# Altium schematic sheet RCU_v2_Supply.SchDoc(RCU_v2_Supply)
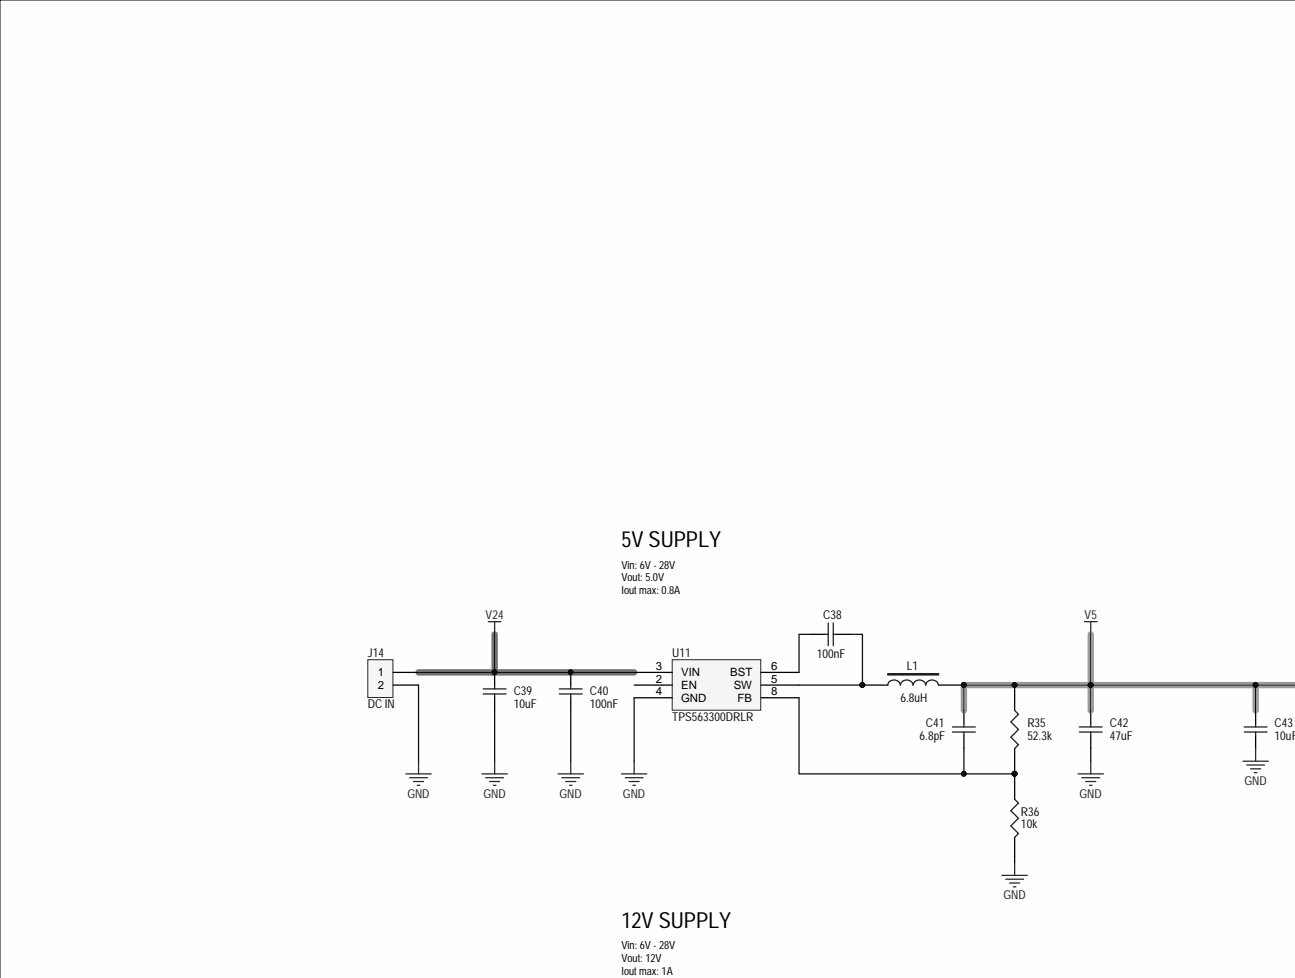
[diagram p0: RCU_v2_Supply.SchDoc, top left]
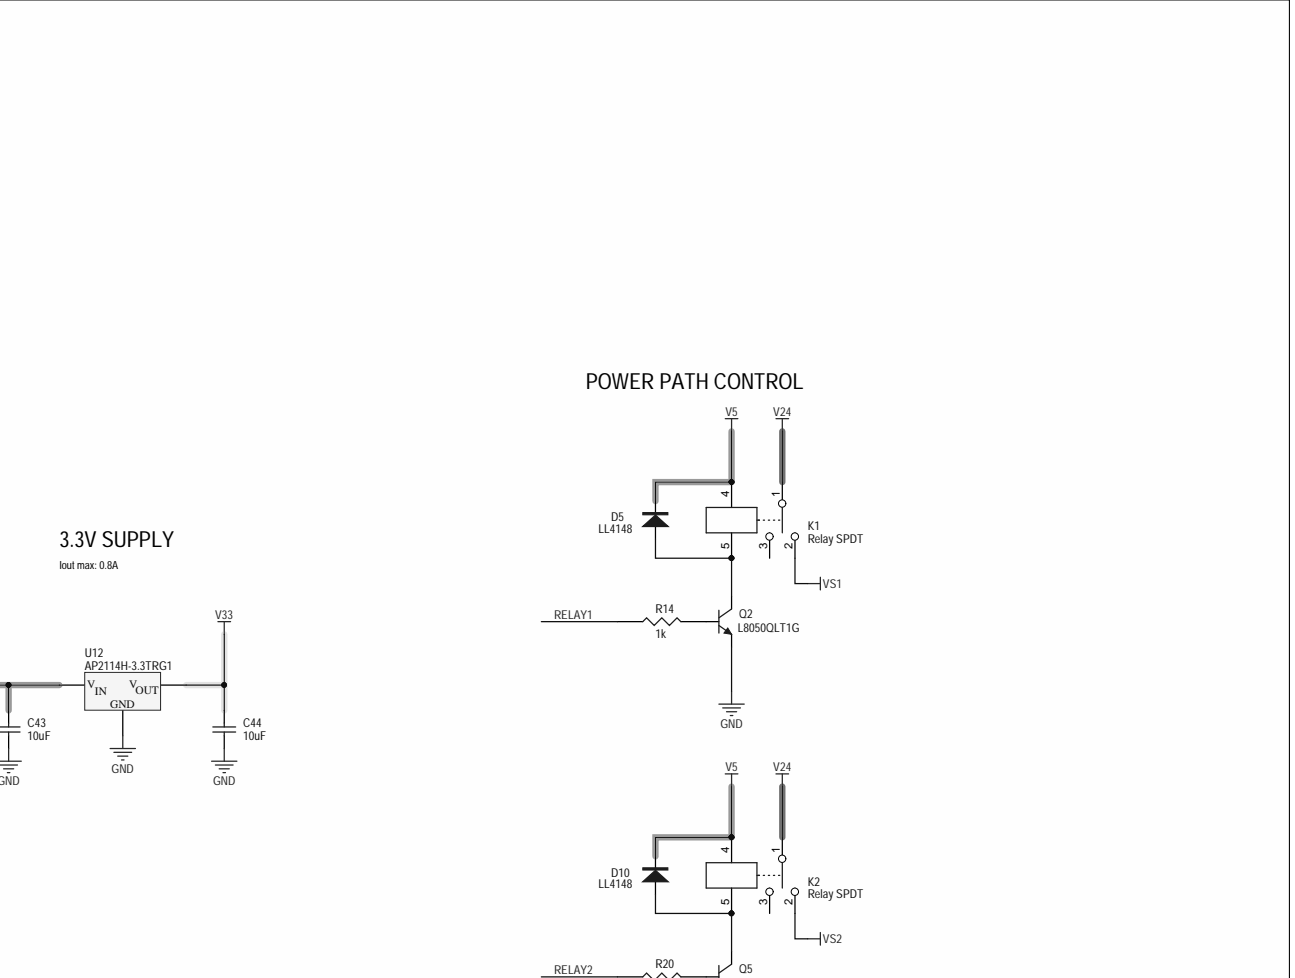
[diagram p1: RCU_v2_Supply.SchDoc, top right]
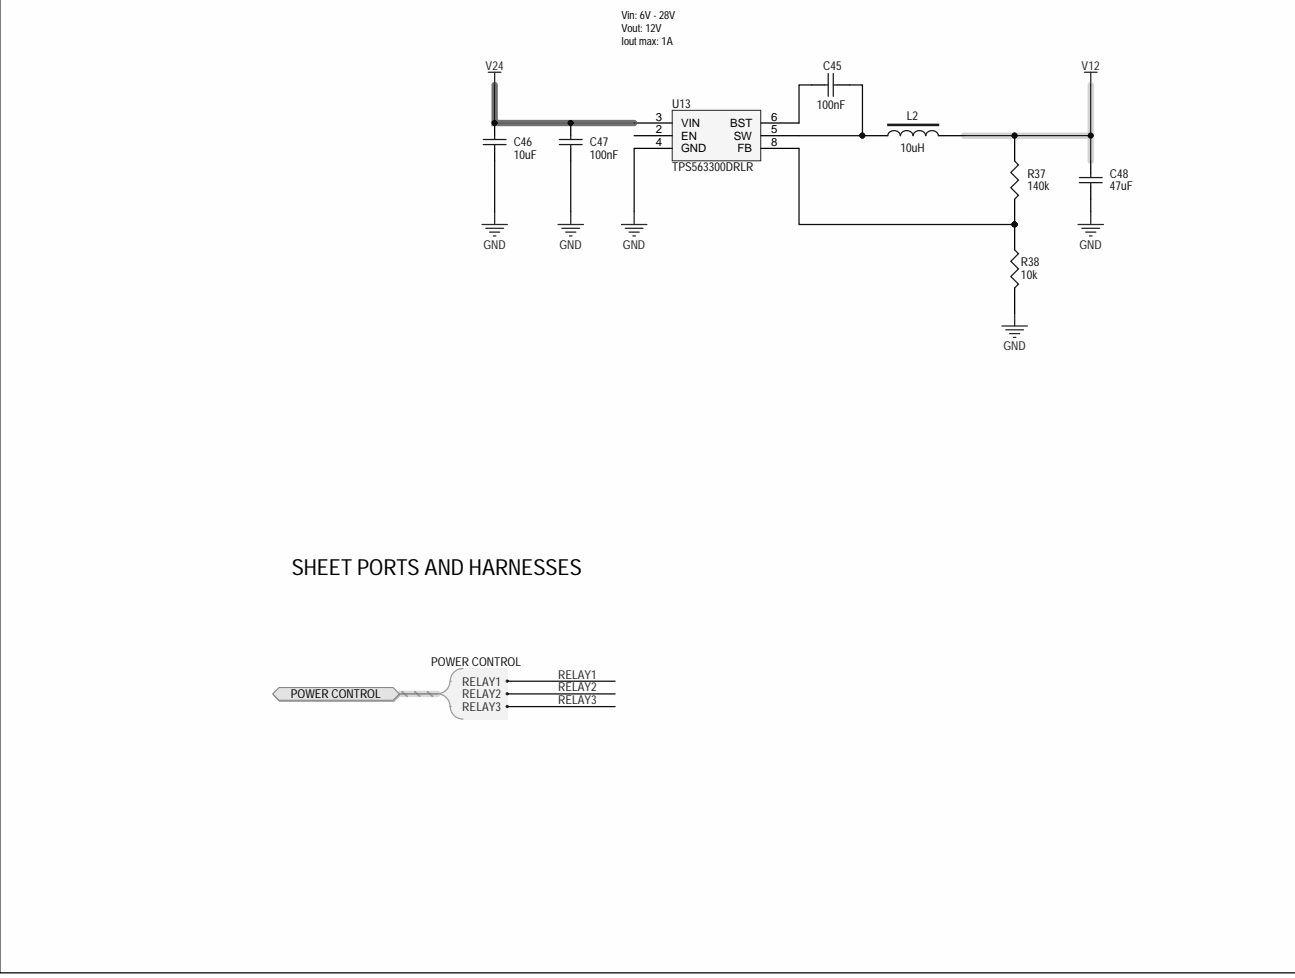
[diagram p2: RCU_v2_Supply.SchDoc, bottom left]
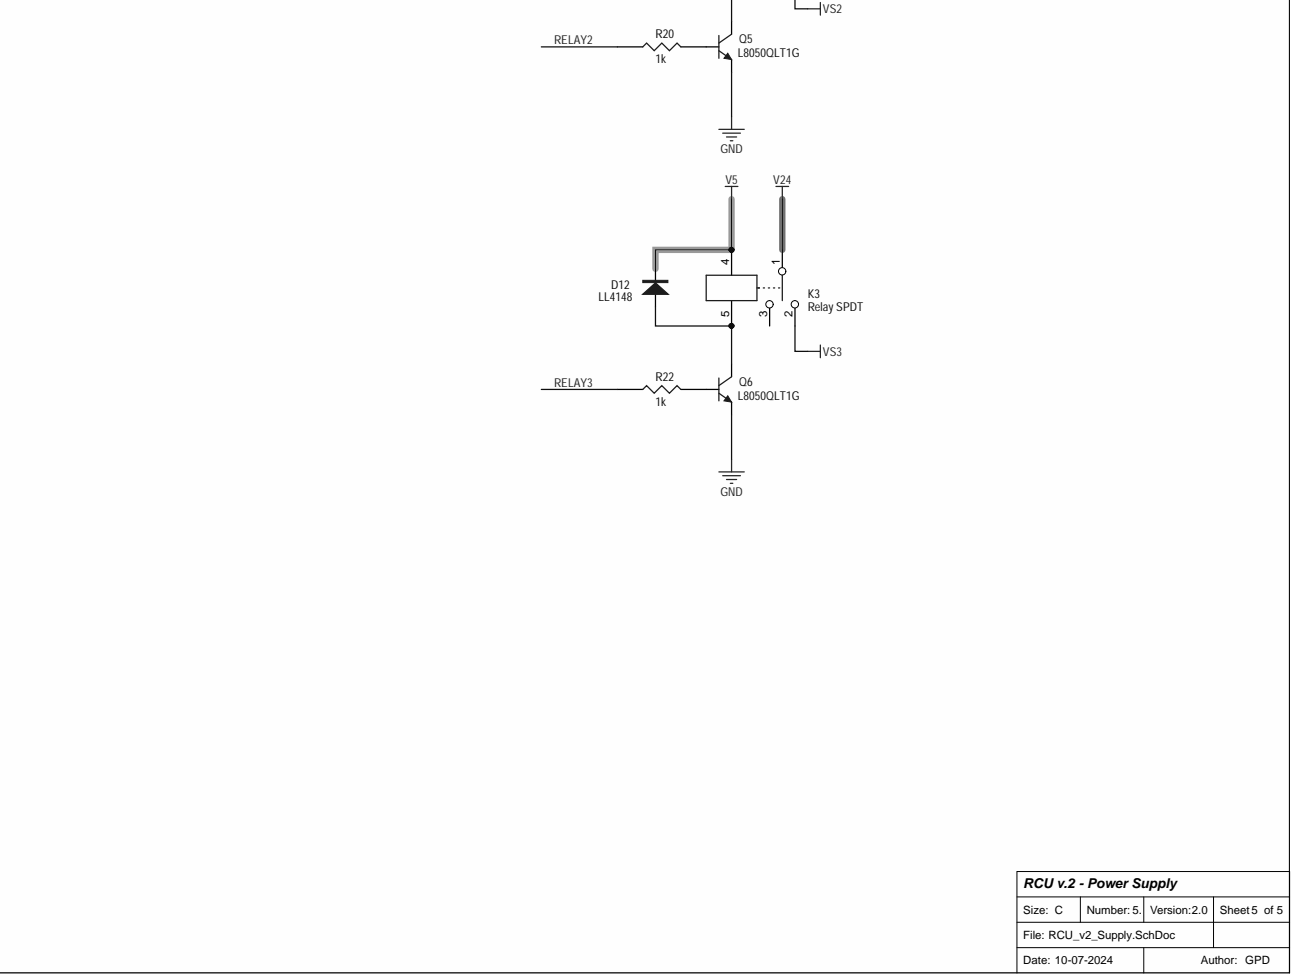
[diagram p3: RCU_v2_Supply.SchDoc, bottom right]

## Parts
C38  100nF  [C0402]
C39  10uF  [C1206]
C40  100nF  [C0603]
C41  6.8pF  [C0402]
C42  47uF  [C1210]
C43  10uF  [C0603]
C44  10uF  [C0603]
C45  100nF  [C0402]
C46  10uF  [C1206]
C47  100nF  [C0603]
C48  47uF  [C1210]
D5  LL4148  [SOD123]
D10  LL4148  [SOD123]
D12  LL4148  [SOD123]
J14  DC IN  [SIP2]
K1  Relay SPDT  [RELAY_AT1RC5V]
K2  Relay SPDT  [RELAY_AT1RC5V]
K3  Relay SPDT  [RELAY_AT1RC5V]
L1  6.8uH  [COIL9]
L2  10uH  [COIL_10]
Q2  L8050QLT1G  [NPN_SMT]
Q5  L8050QLT1G  [NPN_SMT]
Q6  L8050QLT1G  [NPN_SMT]
R14  1k  [R0402]
R20  1k  [R0402]
R22  1k  [R0402]
R35  52.3k  [R0402]
R36  10k  [R0402]
R37  140k  [R0402]
R38  10k  [R0402]
U11  TPS563300DRLR  [SOT-5X3 - 0.6 mm]
U12  AP2114H-3.3TRG1  [DSO-G3/C6.6]
U13  TPS563300DRLR  [SOT-5X3 - 0.6 mm]

## Ports
POWER CONTROL, POWER CONTROL.RELAY1, POWER CONTROL.RELAY2, POWER CONTROL.RELAY3

## Nets
GND: C39.2 C40.2 C42.1 C43.2 C44.2 C46.2 C47.2 C48.1 J14.2 Q2.3 Q5.3 Q6.3 R36.2 R38.2 U11.4(GND) U12.1 U13.4(GND)
V5: C41.1 C42.2 C43.1 D5.2 D10.2 D12.2 K1.4 K2.4 K3.4 L1.2 R35.1 U12.3
V12: C48.2 L2.2 R37.1
V24: C39.1 C40.1 C46.1 C47.1 J14.1 K1.1 K2.1 K3.1 U11.3(VIN) U13.3(VIN)
V33: C44.1 U12.2
VS1: K1.2
VS2: K2.2
VS3: K3.2
RELAY1: R14.1 ; port POWER CONTROL
RELAY2: R20.1 ; port POWER CONTROL
RELAY3: R22.1 ; port POWER CONTROL
NetC38_1: C38.1 U11.6(BST)
NetC38_2: C38.2 L1.1 U11.5(SW)
NetC41_2: C41.2 R35.2 R36.1 U11.8(FB)
NetC45_1: C45.1 U13.6(BST)
NetC45_2: C45.2 L2.1 U13.5(SW)
NetD5_1: D5.1 K1.5 Q2.1
NetD10_1: D10.1 K2.5 Q5.1
NetD12_1: D12.1 K3.5 Q6.1
NetQ2_2: Q2.2 R14.2
NetQ5_2: Q5.2 R20.2
NetQ6_2: Q6.2 R22.2
NetR37_2: R37.2 R38.1 U13.8(FB)

Not connected: K1 3; K2 3; K3 3; U11 2(EN); U13 2(EN)

## Library data of the parts
C38 C45 = CAP: 100nF ±10% 16V X7R 0402 Multilayer Ceramic Capacitors MLCC - SMD/SMT RoHS; Manufacturer Part Number: C0402C104K4RAC-TU; Manufacturer: KEMET; Value: 100nF; Supplier Part Number 3: 399-C0402C104K4RAC7867DKR-ND; Supplier Part Number 2: 8015145; Supplier Part Number 1: 80-C0402C104K4R; Supplier 3: Digikey; Supplier 2: Rs; Supplier 1: Mouser; Library Name: Miscellaneous Devices.SchLib; Component Kind: Standard
C39 C46 = CAP: Multilayer Ceramic Capacitor, 10 uF, 50 V, ± 20%, X5R, 1206 [3216 Metric]; Manufacturer Part Number: UMK316BBJ106ML-T; Manufacturer: Taiyo Yuden; Value: 10uF; Supplier Part Number 3: C97712; Supplier Part Number 2: 963-UMK316BBJ106ML-T; Supplier Part Number 1: 587-3248-6-ND; Supplier 3: LCSC; Supplier 2: Mouser; Supplier 1: Digikey; Library Name: Miscellaneous Devices.SchLib; Component Kind: Standard
C40 C47 = CAP: Multilayer Ceramic Capacitor, 0.1 uF, 50 V, ± 10%, X7R, 0603 [1608 Metric]; Manufacturer Part Number: CC0603KPX7R9BB104; Manufacturer: Yageo; Value: 100nF; Supplier Part Number 3: 603-CC603KPX7R9BB104; Supplier Part Number 2: 3764509RL; Supplier Part Number 1: 311-3567-6-ND; Supplier 3: Mouser; Supplier 2: Farnell; Supplier 1: Digikey; Library Name: Miscellaneous Devices.SchLib; Component Kind: Standard
C41 = CAP: Cap Ceramic 6.8pF 25V C0G 0.25pF SMD 0402 125C Paper T/R; Manufacturer Part Number: GRM1555C1E6R8CA01D; Manufacturer: Murata; Value: 6.8pF; Supplier Part Number 3: C3877782; Supplier Part Number 2: 81-GRM1555C1E6R8CA1D; Supplier Part Number 1: 490-11371-1-ND; Supplier 3: LCSC; Supplier 2: Mouser; Supplier 1: Digikey; Library Name: Miscellaneous Devices.SchLib; Component Kind: Standard
C42 C48 = CAP: Multilayer Ceramic Capacitor, 47 uF, 16 V, ± 10%, X5R, 1210 [3225 Metric]; Manufacturer Part Number: CL32A476KOJNNNE; Manufacturer: Samsung; Value: 47uF; Supplier Part Number 3: 1276-3376-1-ND; Supplier Part Number 2: C2932477; Supplier Part Number 1: 187-CL32A476KOJNNNE; Supplier 3: Digikey; Supplier 2: LCSC; Supplier 1: Mouser; Library Name: Miscellaneous Devices.SchLib; Component Kind: Standard
C43 C44 = CAP: Multilayer Ceramic Capacitor, 10 uF, 16 V, ± 20%, X6S, 0603 [1608 Metric]; Manufacturer Part Number: GRM188C81C106MA73D; Manufacturer: Murata; Value: 10uF; Supplier Part Number 3: 81-GRM188C81C106MA3D; Supplier Part Number 2: 490-7198-1-ND; Supplier Part Number 1: C182375; Supplier 3: Mouser; Supplier 2: Digikey; Supplier 1: LCSC; Library Name: Miscellaneous Devices.SchLib; Component Kind: Standard
D5 D10 D12 = 1N4148: Rectifier Diode Small Signal Switching 100V 0.15A 4ns 2-Pin Mini-MELF T/R; Manufacturer: Diotec; Value: LL4148; Supplier Part Number 3: 637-LL4148; Supplier Part Number 2: 4878-LL4148DKR-ND; Supplier Part Number 1: 4878-LL4148CT-ND; Supplier 3: Mouser; Supplier 2: Digikey; Supplier 1: Digikey; Simulation: 1N4148; Manufacturer Part Number: LL4148; Component Kind: Standard
J14 = CON2: Header Assembly 2 Position MTA100 SPCL BEND MTA-100 Series | TE Connectivity 644874-2; Value: DC IN; Supplier Part Number 2: A114207-ND; Supplier Part Number 1: 571-644874-2; Supplier 2: Digikey; Supplier 1: Mouser; Manufacturer Part Number: 644874-2; Manufacturer: TE Connectivity; Library Name: Miscellaneous Devices.SchLib; Component Kind: Standard
K1-K3 = RELAY-SPDT: SPDT Non Latching Plugin Power Relays Coil 3VDC Contacts 10A 250VAC; Manufacturer Part Number: SRD-05VDC-SL-C; Manufacturer: Ningbo Forward Relay; Value: Relay SPDT; Supplier Part Number 3: C35449; Supplier Part Number 2: C35449; Supplier Part Number 1: C35449; Supplier 3: LCSC; Supplier 2: LCSC; Supplier 1: LCSC; Component Kind: Standard
L1 = INDUCTOR2: Power Choke Shielded Wirewound 6.8uH 20% 100KHz Ferrite 5.5A 22.2mOhm DCR T/R; Manufacturer Part Number: 7443340680; Manufacturer: Wurth Electronics; Value: 6.8uH; Supplier Part Number 3: 2211637; Supplier Part Number 2: 732-4182-6-ND; Supplier Part Number 1: 710-7443340680; Supplier 3: Farnell; Supplier 2: Digikey; Supplier 1: Mouser; Component Kind: Standard
L2 = INDUCTOR2: Power inductor, shielded, 20% tol, SMT, RoHS, halogen f; Manufacturer Part Number: XAL6060-103MEC; Manufacturer: Coilcraft; Value: 10uH; Supplier Part Number 3: 2289091RL; Supplier Part Number 2: 2289091; Supplier Part Number 1: 994-XAL6060-103MEC; Supplier 3: Farnell; Supplier 2: Farnell; Supplier 1: Mouser; Component Kind: Standard
Q2 Q5 Q6 = MMBT3904: 25V 225mW 800mA NPN SOT-23 Bipolar Transistors - BJT ROHS; Manufacturer: LRC; Value: L8050QLT1G; Supplier Part Number 3: C49581; Supplier Part Number 2: C49581; Supplier Part Number 1: C49581; Supplier 3: LCSC; Supplier 2: LCSC; Supplier 1: LCSC; Simulation: MMBT3904; Manufacturer Part Number: L8050QLT1G; Component Kind: Standard
R14 R20 R22 = RES1: SMD Chip Resistor, 1 kOhm, ± 1%, 63 mW, 0402 [1005 Metric], Thick Film, General Purpose; Manufacturer Part Number: CRCW04021K00FKED; Manufacturer: Vishay Dale; Value: 1k; Supplier Part Number 3: C71623; Supplier Part Number 2: 71-CRCW0402-1.0K-E3; Supplier Part Number 1: 541-1.00KLDKR-ND; Supplier 3: LCSC; Supplier 2: Mouser; Supplier 1: Digikey; Component Kind: Standard
R35 = RES1: Res Thin Film 0402 52.3K Ohm 0.1% 0.063W(1/16W) ±25ppm/C Pad SMD T/R; Manufacturer Part Number: RT0402BRD0752K3L; Manufacturer: Yageo; Value: 52.3k; Supplier Part Number 3: YAG1450CT-ND; Supplier Part Number 2: 603-RT0402BRD0752K3L; Supplier Part Number 1: YAG1450DKR-ND; Supplier 3: Digikey; Supplier 2: Mouser; Supplier 1: Digikey; Library Name: Miscellaneous Devices.SchLib; Component Kind: Standard
R36 R38 = RES1: Res Thin Film 0402 10K Ohm 0.1% 0.063W(1/16W) ±50ppm/C Pad SMD T/R; Manufacturer Part Number: RT0402BRE0710KL; Manufacturer: Yageo; Value: 10k; Supplier Part Number 3: YAG2305CT-ND; Supplier Part Number 2: YAG2305DKR-ND; Supplier Part Number 1: 603-RT0402BRE0710KL; Supplier 3: Digikey; Supplier 2: Digikey; Supplier 1: Mouser; Library Name: Miscellaneous Devices.SchLib; Component Kind: Standard
R37 = RES1: SMD Chip Resistor, 140 kOhm, ± 1%, 63 mW, 0402 [1005 Metric], Thick Film, General Purpose; Manufacturer Part Number: RC0402FR-07140KL; Manufacturer: Yageo; Value: 140k; Supplier Part Number 3: YAG2978CT-ND; Supplier Part Number 2: 603-RC0402FR-07140KL; Supplier Part Number 1: C114151; Supplier 3: Digikey; Supplier 2: Mouser; Supplier 1: LCSC; Library Name: Miscellaneous Devices.SchLib; Component Kind: Standard
U11 U13 = TPS563300: 3.8-V to 28-V Input, 3-A synchronous buck converter in SOT-583 package 8-SOT-5X3 -40 to 150; Manufacturer: Texas Instruments; Value: TPS563300DRLR; Supplier Part Number 3: 296-TPS563300DRLRCT-ND; Supplier Part Number 2: 595-TPS563300DRLR; Supplier Part Number 1: TPS563300DRLR; Supplier 3: Digikey; Supplier 2: Mouser; Supplier 1: Texas Instruments; Manufacturer Part Number: TPS563300DRLR; Library Name: Power.SchLib; ComponentLink1URL: https://www.ti.com/lit/gpn/tps563300; ComponentLink1Description: Datasheet; Component Kind: Standard
U12 = 78L05: IC REG LDO 3.3V 1A SOT223; Manufacturer: Diodes; Value: AP2114H-3.3TRG1; Supplier Part Number 3: 621-AP2114H-3.3TRG1; Supplier Part Number 2: AP2114H-3.3TRG1DIDKR-ND; Supplier Part Number 1: C150716; Supplier 3: Mouser; Supplier 2: Digikey; Supplier 1: LCSC; Simulation: 78L05; Manufacturer Part Number: AP2114H-3.3TRG1; Library Name: REGULATOR.SchLib; ComponentLink1URL: https://datasheet.lcsc.com/lcsc/2304140030_Diodes-Incorporated-AP2114H-3-3TRG1_C150716.pdf; ComponentLink1Description: Datasheet; Component Kind: Standard
